# Altium schematic sheet Power.SchDoc(Power)
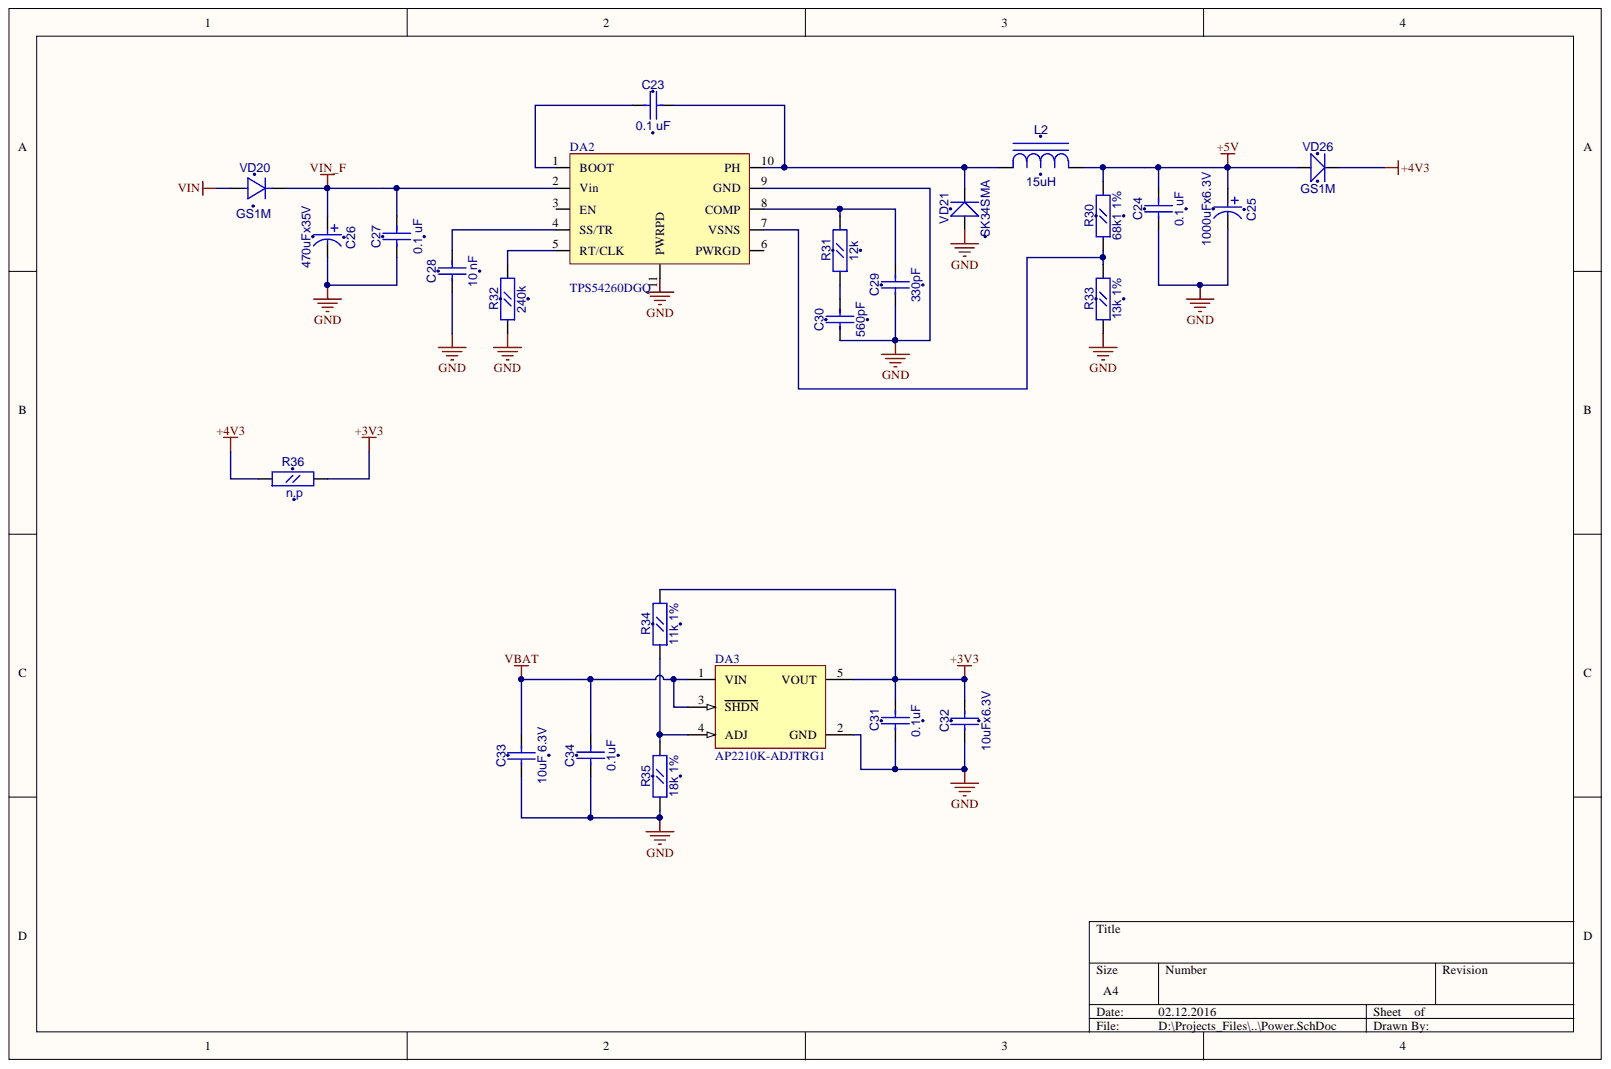
[diagram p0: Power.SchDoc]

## Parts
C23  0.1 uF  [CC0603]
C24  0.1 uF  [CC0603]
C25  1000uFx6.3V  [CC8X3.5]
C26  470uFx35V  [CC16X10_CAP0.5(1)(Primary)]
C27  0.1 uF  [CC0603]
C28  10 nF  [CC0603]
C29  330pF  [CC0603]
C30  560pF  [CC0603]
C31  0.1uF  [CC0603]
C32  10uFx6.3V  [CC0805]
C33  10uF 6.3V  [CC0805]
C34  0.1uF  [CC0603]
DA2  TPS54260DGQ  [S-PDSO-G10]
DA3  AP2210K-ADJTRG1  [SOT23-5]
L2  15uH  [SDR0805]
R30  68k1 1%  [RC0603]
R31  12k  [RC0603]
R32  240k  [RC0603]
R33  13k 1%  [RC0603]
R34  11k 1%  [RC0603]
R35  18k 1%  [RC0603]
R36  n.p  [RC0805]
VD20  GS1M  [SMA]
VD21  SK34SMA  [SMA]
VD26  GS1M  [SMA]

## Nets
+3V3: C31.1 C32.2 DA3.5(VOUT) R34.2 R36.2
+4V3: R36.1 VD26.2
+5V: C24.1 C25.1 L2.2 R30.2 VD26.1
GND: C24.2 C25.2 C26.1 C27.2 C28.2 C29.2 C30.2 C31.2 C32.1 C33.1 C34.2 DA2.9(GND) DA2.11(PWRPD) DA3.2(GND) R32.1 R33.1 R35.1 VD21.1
VBAT: C33.2 C34.1 DA3.1(VIN) DA3.3(SHDN)
VIN: VD20.1
VIN_F: C26.2 C27.1 DA2.2(Vin) VD20.2
NetC23_1: C23.1 DA2.10(PH) L2.1 VD21.2
NetC23_2: C23.2 DA2.1(BOOT)
NetC28_1: C28.1 DA2.4(SS/TR)
NetC29_1: C29.1 DA2.8(COMP) R31.2
NetC30_1: C30.1 R31.1
NetDA2_5: DA2.5(RT/CLK) R32.2
NetDA2_7: DA2.7(VSNS) R30.1 R33.2
NetDA3_4: DA3.4(ADJ) R34.1 R35.2

Not connected: DA2 3(EN) 6(PWRGD)

## Library data of the parts
C23 C24 C27-C31 C34 = CC0603: Component Kind: Standard; Description: CC0603; Library Name: gprs_modem_2.SchLib
  C23 C24 C27: Value: 0.1 uF
  C28: Value: 10 nF
  C29: Value: 330pF
  C30: Value: 560pF
  C31 C34: Value: 0.1uF
C25 = CAP0.35: Component Kind: Standard; Description: CAP0.35; Value: 1000uFx6.3V; Library Name: gprs_modem_2.SchLib
C26 = CAP0.5(1): Component Kind: Standard; Description: CAP0.5(1); Value: 470uFx35V; Library Name: gprs_modem_2.SchLib
C32 C33 = CC0805: Component Kind: Standard; Description: CC0805; Library Name: gprs_modem_2.SchLib
  C32: Value: 10uFx6.3V
  C33: Value: 10uF 6.3V
DA3 = MCP1824T-ADJE/OT: 300 mA, Low Voltage, Low Quiescent Current LDO Regulator, 5-Pin SOT-223, Extended Temperature, Tape and Reel; Component Kind: Standard; ComponentLink1Description: Manufacturer Link; ComponentLink1URL: http://www.microchip.com; ComponentLink2Description: Datasheet; ComponentLink2URL: http://ww1.microchip.com/downloads/en/DeviceDoc/22070a.pdf; ComponentLink3Description: Packaging Specification; ComponentLink3URL: http://ww1.microchip.com/downloads/en/PackagingSpec/00049AW.pdf; DatasheetVersion: revA, Aug-2007; PackageDescription: 5-Lead Plastic Small Outline Transistor (DC) [SOT-223]; PackageReference: SOT-223-DC5; PackageVersion: revAW, Oct-2008; Published: 29-Jan-2009; Library Name: gprs_modem_2.SchLib; Publisher: Altium Limited
L2 = SDR0805: Component Kind: Standard; Description: SDR0805; Value: 15uH; Library Name: gprs_modem_2.SchLib
R30-R35 = RC0603: Component Kind: Standard; Description: RC0603; Library Name: gprs_modem_2.SchLib
  R30: Value: 68k1 1%
  R31: Value: 12k
  R32: Value: 240k
  R33: Value: 13k 1%
  R34: Value: 11k 1%
  R35: Value: 18k 1%
R36 = RC0805: Component Kind: Standard; Description: RC0805; Value: n.p; Library Name: gprs_modem_2.SchLib
VD20 = GS1M: Value: {Value}; Component Kind: Standard; Description: GS1M; Library Name: gprs_modem_2.SchLib
VD21 = SK34SMA: Schottky Rectifier; Value: {Value}; Component Kind: Standard; Library Name: gprs_modem.SchLib
VD26 = SS14: Schottky Rectifier; ComponentField6: Published 8-Jun-2000, Modified 10-July-2000: SIM Model Added.; OriginalName: 10BQ100; Component Kind: Standard; Simulation: 10BQ100; Value: GS1M; Library Name: gprs_modem_2.SchLib
DA2 = TPS54260DGQ: Component Kind: Standard; Library Name: gprs_modem_2.SchLib
